# Altium schematic sheet MCU.SchDoc(MCU)
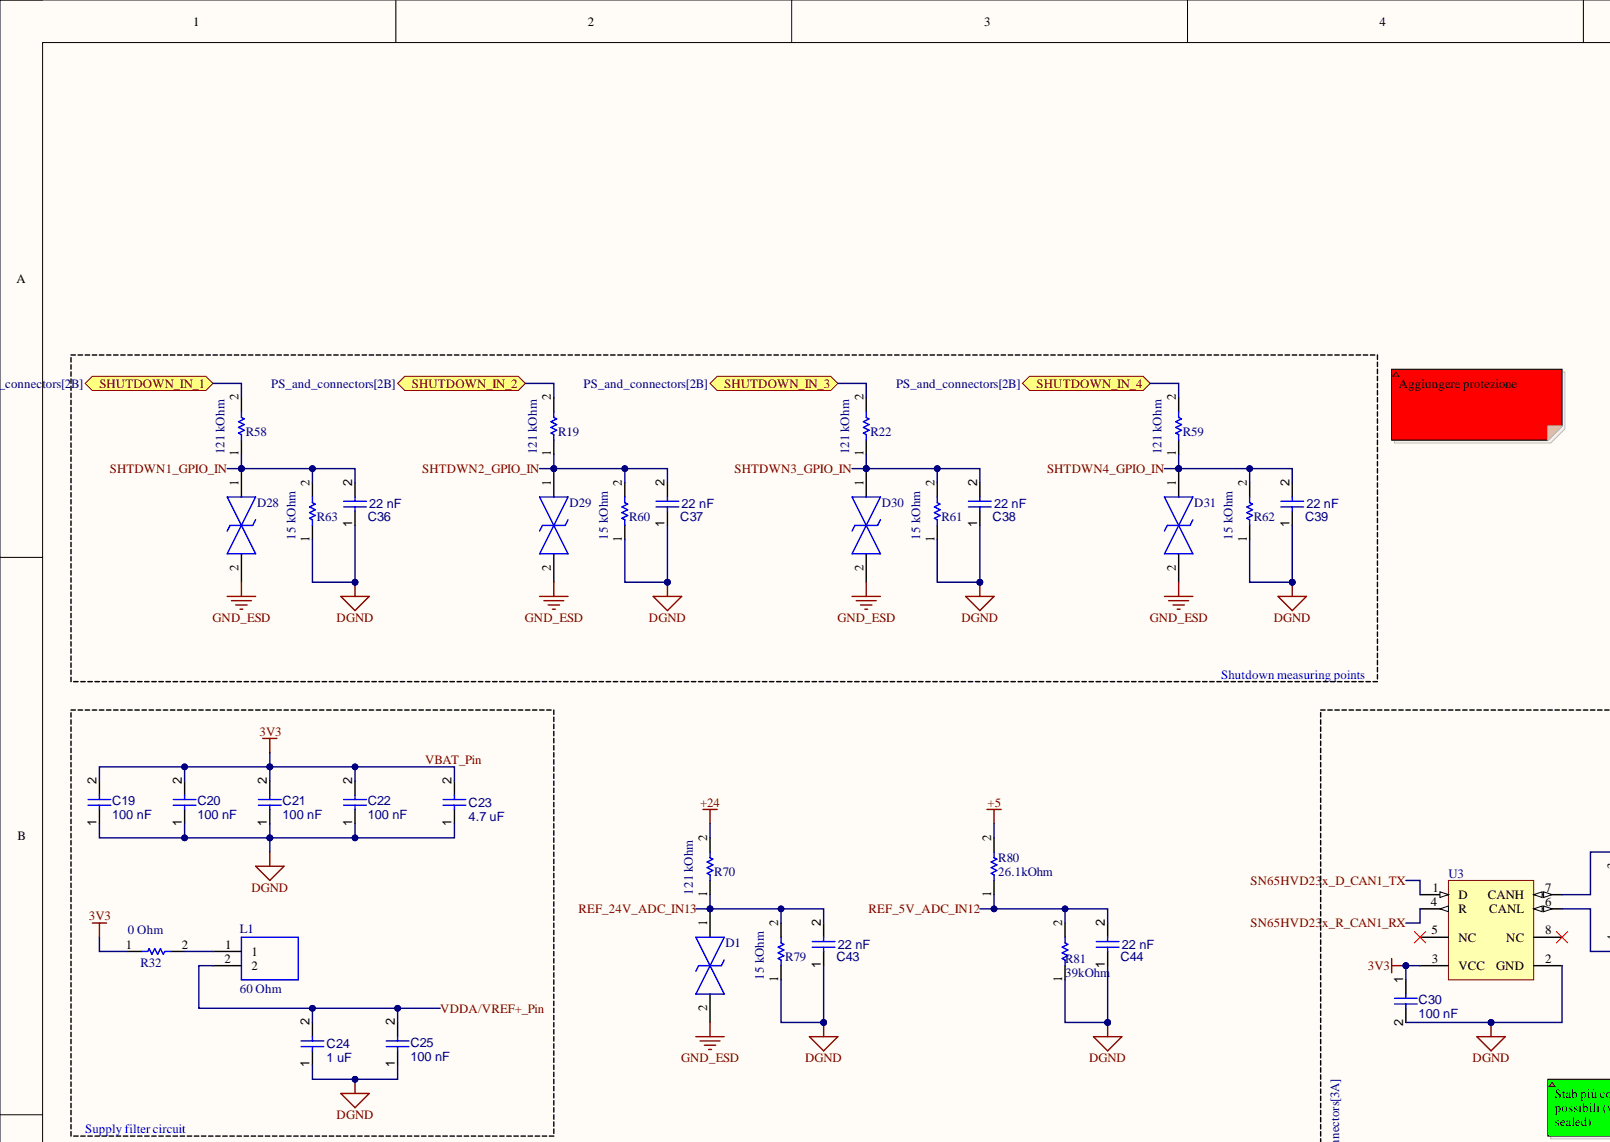
[diagram p0: MCU.SchDoc, top left]
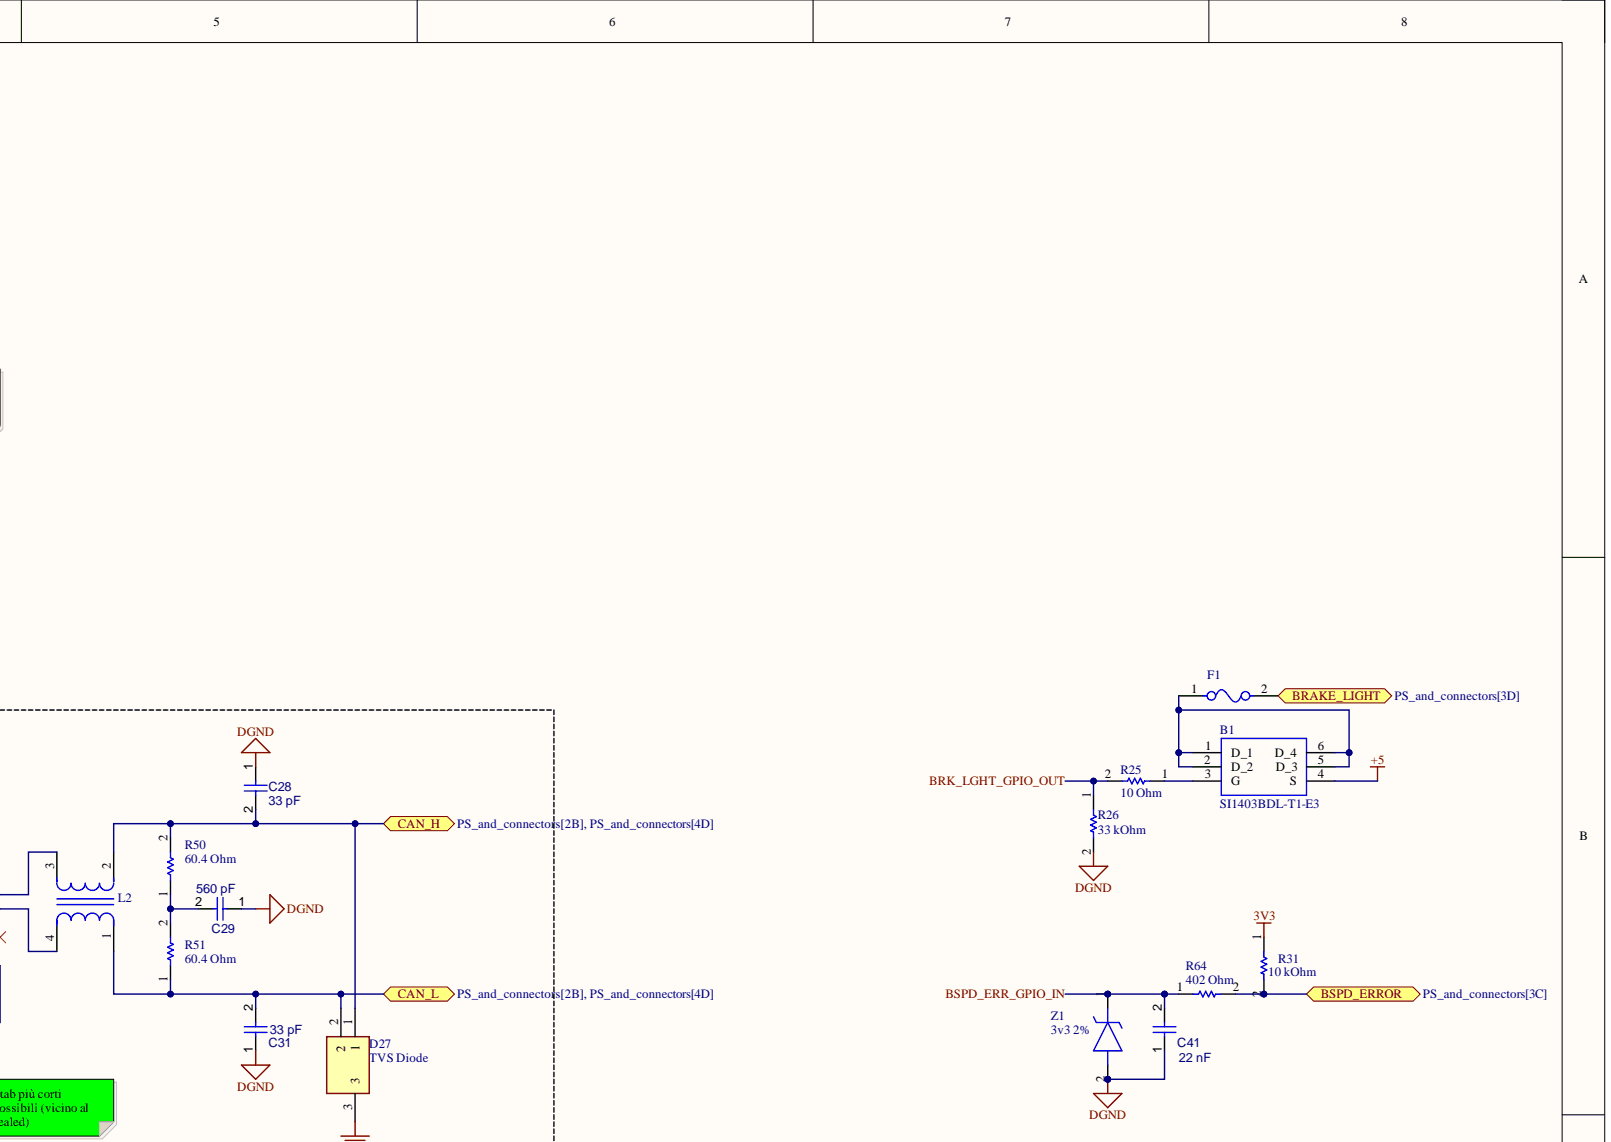
[diagram p1: MCU.SchDoc, top right]
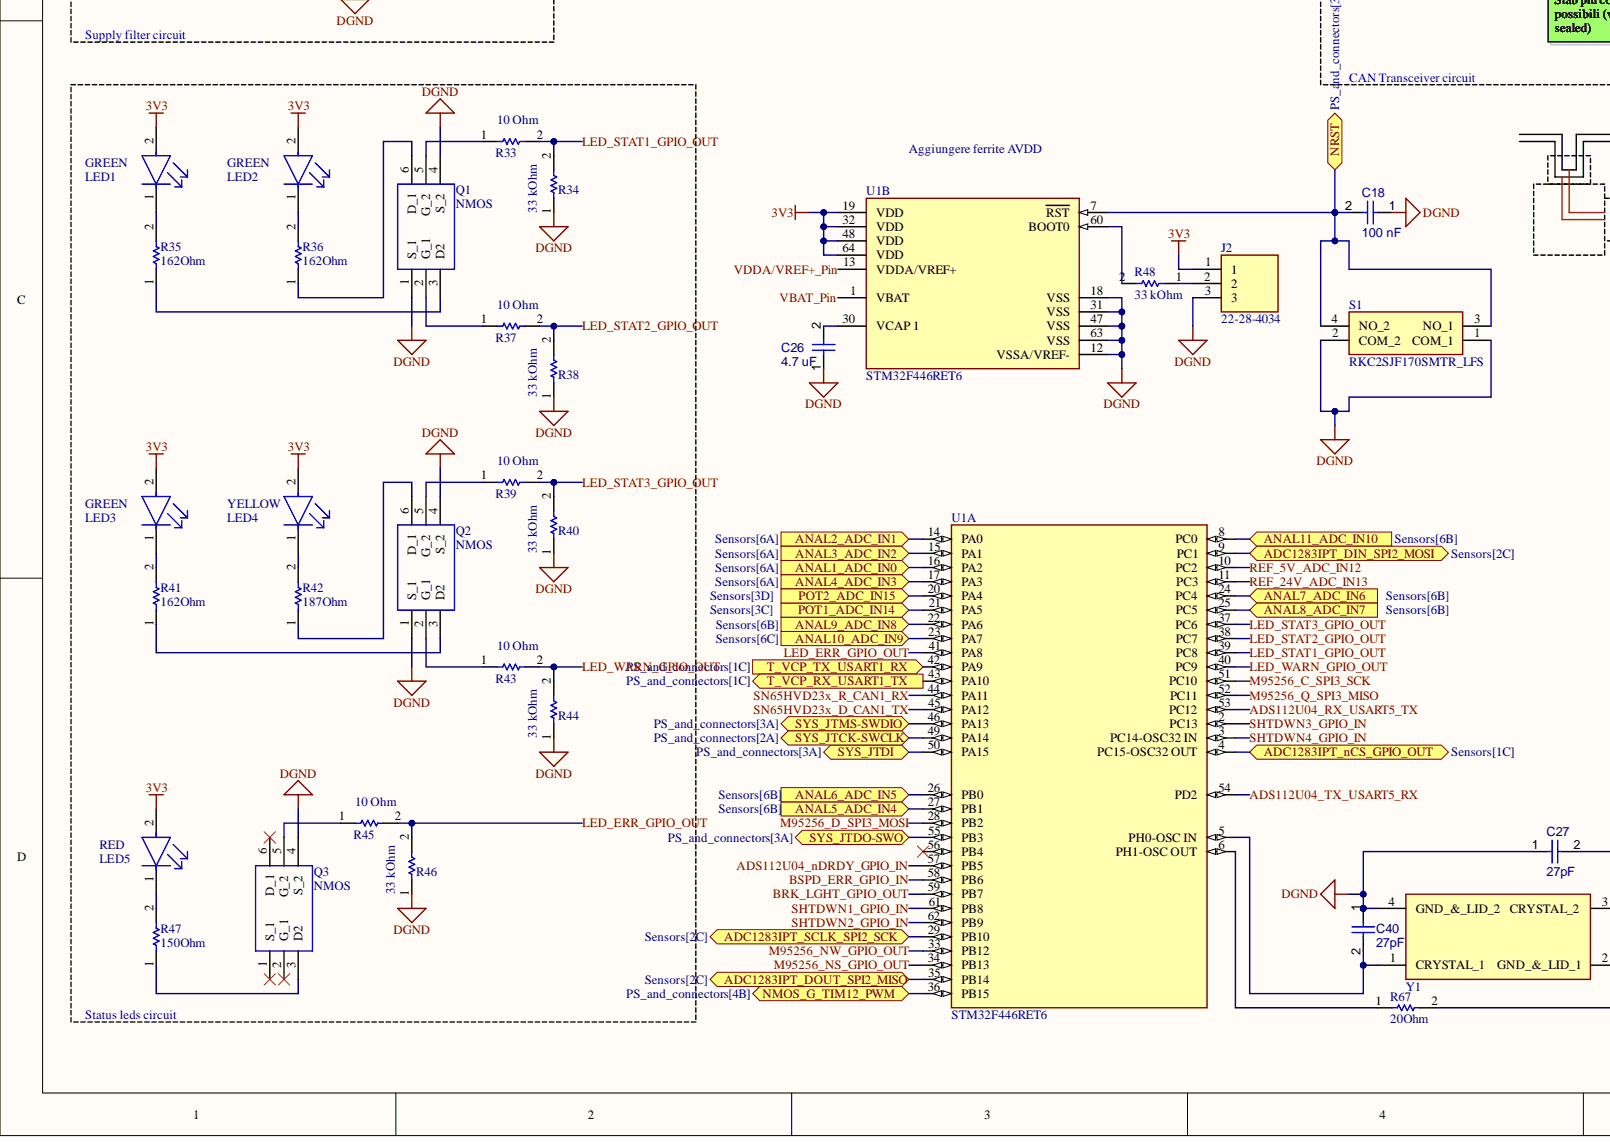
[diagram p2: MCU.SchDoc, bottom left]
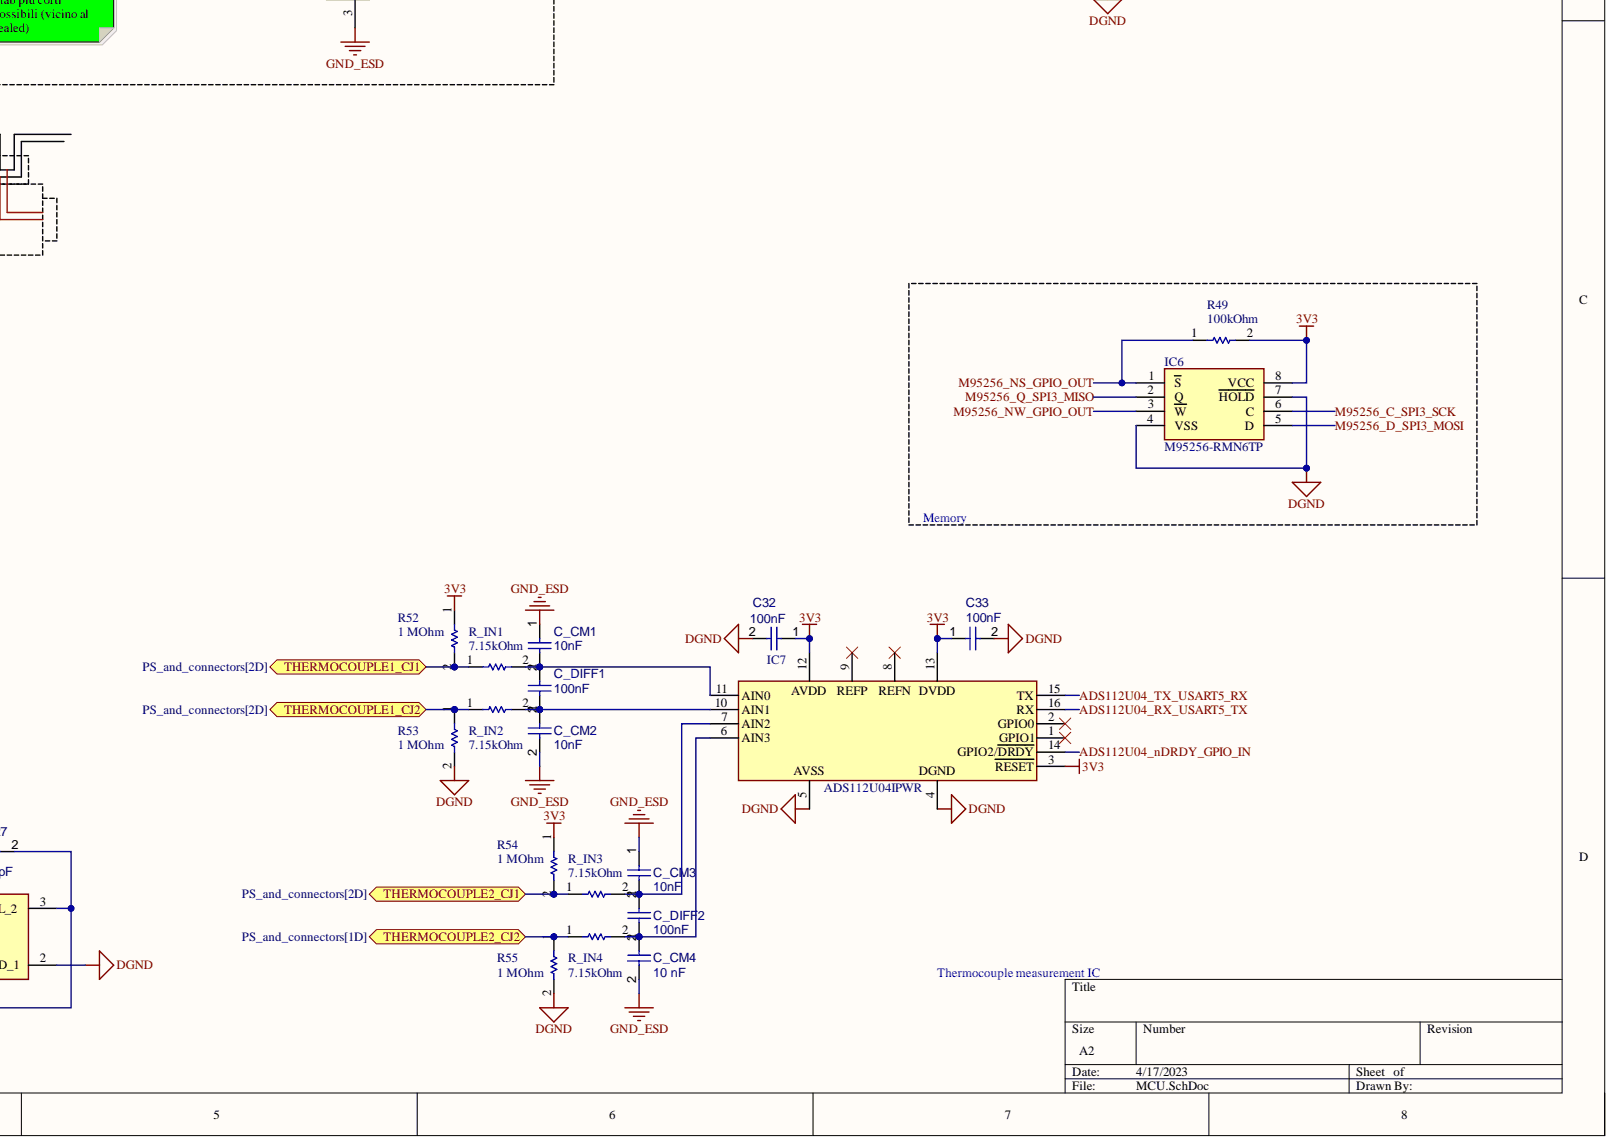
[diagram p3: MCU.SchDoc, bottom right]

## Parts
B1  SI1403BDL-T1-E3
C18  100 nF
C19  100 nF
C20  100 nF
C21  100 nF
C22  100 nF
C23  4.7 uF
C24  1 uF
C25  100 nF
C26  4.7 uF
C27  27pF
C28  33 pF
C29  560 pF
C30  100 nF
C31  33 pF
C32  100nF
C33  100nF
C36  22 nF
C37  22 nF
C38  22 nF
C39  22 nF
C40  27pF
C41  22 nF
C43  22 nF
C44  22 nF
C_CM1  10nF
C_CM2  10nF
C_CM3  10nF
C_CM4  10 nF
C_DIFF1  100nF
C_DIFF2  100nF
D1  SMAJ30CA-TR  (value not drawn)
D27  TVS Diode
D28  SMAJ30CA-TR  (value not drawn)
D29  SMAJ30CA-TR  (value not drawn)
D30  SMAJ30CA-TR  (value not drawn)
D31  SMAJ30CA-TR  (value not drawn)
F1  0466001.NR  (value not drawn)
IC6  M95256-RMN6TP
IC7  ADS112U04IPWR
J2  22-28-4034
L1  60 Ohm
L2  ACT45B-101-2P-TL003  (value not drawn)
LED1  GREEN
LED2  GREEN
LED3  GREEN
LED4  YELLOW
LED5  RED
Q1  NMOS
Q2  NMOS
Q3  NMOS
R19  121 kOhm
R22  121 kOhm
R25  10 Ohm
R26  33 kOhm
R31  10 kOhm
R32  0 Ohm
R33  10 Ohm
R34  33 kOhm
R35  162Ohm
R36  162Ohm
R37  10 Ohm
R38  33 kOhm
R39  10 Ohm
R40  33 kOhm
R41  162Ohm
R42  187Ohm
R43  10 Ohm
R44  33 kOhm
R45  10 Ohm
R46  33 kOhm
R47  150Ohm
R48  33 kOhm
R49  100kOhm
R50  60.4 Ohm
R51  60.4 Ohm
R52  1 MOhm
R53  1 MOhm
R54  1 MOhm
R55  1 MOhm
R58  121 kOhm
R59  121 kOhm
R60  15 kOhm
R61  15 kOhm
R62  15 kOhm
R63  15 kOhm
R64  402 Ohm
R67  20Ohm
R70  121 kOhm
R79  15 kOhm
R80  26.1kOhm
R81  39kOhm
R_IN1  7.15kOhm
R_IN2  7.15kOhm
R_IN3  7.15kOhm
R_IN4  7.15kOhm
S1  RKC2SJF170SMTR_LFS
U1  STM32F446RET6  (parts A,B)
U3  SN65HVD232DRG4  [SN65HVD232]  (value not drawn)
Y1  830055663  (value not drawn)
Z1  3v3 2%

## Ports
ADC1283IPT_DIN_SPI2_MOSI, ADC1283IPT_DOUT_SPI2_MISO, ADC1283IPT_nCS_GPIO_OUT, ADC1283IPT_SCLK_SPI2_SCK, ANAL1_ADC_IN0, ANAL2_ADC_IN1, ANAL3_ADC_IN2, ANAL4_ADC_IN3, ANAL5_ADC_IN4, ANAL6_ADC_IN5, ANAL7_ADC_IN6, ANAL8_ADC_IN7, ANAL9_ADC_IN8, ANAL10_ADC_IN9, ANAL11_ADC_IN10, BRAKE_LIGHT, BSPD_ERROR, CAN_H, CAN_L, NMOS_G_TIM12_PWM, NRST, POT1_ADC_IN14, POT2_ADC_IN15, SHUTDOWN_IN_1, SHUTDOWN_IN_2, SHUTDOWN_IN_3, SHUTDOWN_IN_4, SYS_JTCK-SWCLK, SYS_JTDI, SYS_JTDO-SWO, SYS_JTMS-SWDIO, T_VCP_RX_USART1_TX, T_VCP_TX_USART1_RX, THERMOCOUPLE1_CJ1, THERMOCOUPLE1_CJ2, THERMOCOUPLE2_CJ1, THERMOCOUPLE2_CJ2

## Nets
+5: B1.4(S) R80.2
+24: R70.2
DGND: C18.1 C19.1 C20.1 C21.1 C22.1 C23.1 C24.1 C25.1 C26.1 C27.1 C28.1 C29.1 C30.2 C31.1 C32.2 C33.2 C36.1 C37.1 C38.1 C39.1 C40.1 C41.1 C43.1 C44.1 IC6.4(VSS) IC6.7(HOLD) IC7.4 IC7.5 J2.3 Q1.1(S_1) Q1.4(S_2) Q2.1(S_1) Q2.4(S_2) Q3.4(S_2) R26.2 R34.1 R38.1 R40.1 R44.1 R46.1 R53.2 R55.2 R60.1 R61.1 R62.1 R63.1 R79.1 R81.1 S1.1(COM_1) S1.2(COM_2) U1.12(VSSA/VREF-) U1.18(VSS) U1.31(VSS) U1.47(VSS) U1.63(VSS) U3.2(GND) Y1.2(GND_&_LID_1) Y1.4(GND_&_LID_2) Z1.2
GND_ESD: C_CM1.1 C_CM2.2 C_CM3.1 C_CM4.2 D1.2 D27.3 D28.2 D29.2 D30.2 D31.2
ADC1283IPT_DIN_SPI2_MOSI: U1.9(PC1) ; port ADC1283IPT_DIN_SPI2_MOSI
ADC1283IPT_DOUT_SPI2_MISO: U1.35(PB14) ; port ADC1283IPT_DOUT_SPI2_MISO
ADC1283IPT_nCS_GPIO_OUT: U1.4(PC15-OSC32_OUT) ; port ADC1283IPT_nCS_GPIO_OUT
ADC1283IPT_SCLK_SPI2_SCK: U1.29(PB10) ; port ADC1283IPT_SCLK_SPI2_SCK
ADS112U04_nDRDY_GPIO_IN: IC7.14(GPIO2/DRDY) U1.57(PB5)
ADS112U04_RX_USART5_TX: IC7.16(RX) U1.53(PC12)
ADS112U04_TX_USART5_RX: IC7.15(TX) U1.54(PD2)
ANAL1_ADC_IN0: U1.16(PA2) ; port ANAL1_ADC_IN0
ANAL2_ADC_IN1: U1.14(PA0) ; port ANAL2_ADC_IN1
ANAL3_ADC_IN2: U1.15(PA1) ; port ANAL3_ADC_IN2
ANAL4_ADC_IN3: U1.17(PA3) ; port ANAL4_ADC_IN3
ANAL5_ADC_IN4: U1.27(PB1) ; port ANAL5_ADC_IN4
ANAL6_ADC_IN5: U1.26(PB0) ; port ANAL6_ADC_IN5
ANAL7_ADC_IN6: U1.24(PC4) ; port ANAL7_ADC_IN6
ANAL8_ADC_IN7: U1.25(PC5) ; port ANAL8_ADC_IN7
ANAL9_ADC_IN8: U1.22(PA6) ; port ANAL9_ADC_IN8
ANAL10_ADC_IN9: U1.23(PA7) ; port ANAL10_ADC_IN9
ANAL11_ADC_IN10: U1.8(PC0) ; port ANAL11_ADC_IN10
BRAKE_LIGHT: F1.2 ; port BRAKE_LIGHT
BRK_LGHT_GPIO_OUT: R25.2 R26.1 U1.59(PB7)
BSPD_ERR_GPIO_IN: C41.2 R64.1 U1.58(PB6) Z1.1
BSPD_ERROR: R31.2 R64.2 ; port BSPD_ERROR
CAN_H: C28.2 D27.1 L2.2 R50.2 ; port CAN_H
CAN_L: C31.2 D27.2 L2.1 R51.1 ; port CAN_L
LED_ERR_GPIO_OUT: R45.2 R46.2 U1.41(PA8)
LED_STAT1_GPIO_OUT: R33.2 R34.2 U1.39(PC8)
LED_STAT2_GPIO_OUT: R37.2 R38.2 U1.38(PC7)
LED_STAT3_GPIO_OUT: R39.2 R40.2 U1.37(PC6)
LED_WARN_GPIO_OUT: R43.2 R44.2 U1.40(PC9)
M95256_C_SPI3_SCK: IC6.6(C) U1.51(PC10)
M95256_D_SPI3_MOSI: IC6.5(D) U1.28(PB2)
M95256_NS_GPIO_OUT: IC6.1(S) R49.1 U1.34(PB13)
M95256_NW_GPIO_OUT: IC6.3(W) U1.33(PB12)
M95256_Q_SPI3_MISO: IC6.2(Q) U1.52(PC11)
NMOS_G_TIM12_PWM: U1.36(PB15) ; port NMOS_G_TIM12_PWM
NRST: C18.2 S1.3(NO_1) S1.4(NO_2) U1.7(RST) ; port NRST
POT1_ADC_IN14: U1.21(PA5) ; port POT1_ADC_IN14
POT2_ADC_IN15: U1.20(PA4) ; port POT2_ADC_IN15
REF_5V_ADC_IN12: C44.2 R80.1 R81.2 U1.10(PC2)
REF_24V_ADC_IN13: C43.2 D1.1 R70.1 R79.2 U1.11(PC3)
SHTDWN1_GPIO_IN: C36.2 D28.1 R58.1 R63.2 U1.61(PB8)
SHTDWN2_GPIO_IN: C37.2 D29.1 R19.1 R60.2 U1.62(PB9)
SHTDWN3_GPIO_IN: C38.2 D30.1 R22.1 R61.2 U1.2(PC13)
SHTDWN4_GPIO_IN: C39.2 D31.1 R59.1 R62.2 U1.3(PC14-OSC32_IN)
SHUTDOWN_IN_1: R58.2 ; port SHUTDOWN_IN_1
SHUTDOWN_IN_2: R19.2 ; port SHUTDOWN_IN_2
SHUTDOWN_IN_3: R22.2 ; port SHUTDOWN_IN_3
SHUTDOWN_IN_4: R59.2 ; port SHUTDOWN_IN_4
SN65HVD23x_D_CAN1_TX: U1.45(PA12) U3.1(D)
SN65HVD23x_R_CAN1_RX: U1.44(PA11) U3.4(R)
SYS_JTCK-SWCLK: U1.49(PA14) ; port SYS_JTCK-SWCLK
SYS_JTDI: U1.50(PA15) ; port SYS_JTDI
SYS_JTDO-SWO: U1.55(PB3) ; port SYS_JTDO-SWO
SYS_JTMS-SWDIO: U1.46(PA13) ; port SYS_JTMS-SWDIO
T_VCP_RX_USART1_TX: U1.43(PA10) ; port T_VCP_RX_USART1_TX
T_VCP_TX_USART1_RX: U1.42(PA9) ; port T_VCP_TX_USART1_RX
THERMOCOUPLE1_CJ1: R52.2 R_IN1.1 ; port THERMOCOUPLE1_CJ1
THERMOCOUPLE1_CJ2: R53.1 R_IN2.1 ; port THERMOCOUPLE1_CJ2
THERMOCOUPLE2_CJ1: R54.2 R_IN3.1 ; port THERMOCOUPLE2_CJ1
THERMOCOUPLE2_CJ2: R55.1 R_IN4.1 ; port THERMOCOUPLE2_CJ2
VBAT_Pin: C19.2 C20.2 C21.2 C22.2 C23.2 C30.1 C32.1 C33.1 IC6.8(VCC) IC7.3(RESET) IC7.12 IC7.13 J2.1 LED1.2 LED2.2 LED3.2 LED4.2 LED5.2 R31.1 R32.1 R49.2 R52.1 R54.1 U1.1(VBAT) U1.19(VDD) U1.32(VDD) U1.48(VDD) U1.64(VDD) U3.3(VCC)
VDDA/VREF+_Pin: C24.2 C25.2 L1.2 U1.13(VDDA/VREF+)
NetB1_1: B1.1(D_1) B1.2(D_2) B1.5(D_3) B1.6(D_4) F1.1
NetB1_3: B1.3(G) R25.1
NetC26_2: C26.2 U1.30(VCAP_1)
NetC27_2: C27.2 R67.2 Y1.3(CRYSTAL_2)
NetC29_2: C29.2 R50.1 R51.2
NetC40_2: C40.2 U1.5(PH0-OSC_IN) Y1.1(CRYSTAL_1)
NetC_CM1_2: C_CM1.2 C_DIFF1.1 IC7.11(AIN0) R_IN1.2
NetC_CM2_1: C_CM2.1 C_DIFF1.2 IC7.10(AIN1) R_IN2.2
NetC_CM3_2: C_CM3.2 C_DIFF2.1 IC7.7(AIN2) R_IN3.2
NetC_CM4_1: C_CM4.1 C_DIFF2.2 IC7.6(AIN3) R_IN4.2
NetJ2_2: J2.2 R48.1
NetL1_1: L1.1 R32.2
NetL2_3: L2.3 U3.7(CANH)
NetL2_4: L2.4 U3.6(CANL)
NetLED1_1: LED1.1 R35.2
NetLED2_1: LED2.1 R36.2
NetLED3_1: LED3.1 R41.2
NetLED4_1: LED4.1 R42.2
NetLED5_1: LED5.1 R47.2
NetQ1_2: Q1.2(G_1) R37.1
NetQ1_3: Q1.3(D2) R35.1
NetQ1_5: Q1.5(G_2) R33.1
NetQ1_6: Q1.6(D_1) R36.1
NetQ2_2: Q2.2(G_1) R43.1
NetQ2_3: Q2.3(D2) R41.1
NetQ2_5: Q2.5(G_2) R39.1
NetQ2_6: Q2.6(D_1) R42.1
NetQ3_3: Q3.3(D2) R47.1
NetQ3_5: Q3.5(G_2) R45.1
NetR48_2: R48.2 U1.60(BOOT0)
NetR67_1: R67.1 U1.6(PH1-OSC_OUT)

Not connected: IC7 1(GPIO1) 2(GPIO0) 8 9; Q3 1(S_1) 2(G_1) 6(D_1); U1 56(PB4); U3 5(NC) 8(NC)

## Library data of the parts
B1 = CMP-002-000009-1: SI1403BDL-T1-E3, P-channel MOSFET Transistor 1.4 A 20 V, 6-Pin SOT-363; Manufacturer_Name: Vishay; Geometry.Height: 1.1mm; Component Kind: Standard; Datasheet Link: https://datasheet.datasheetarchive.com/originals/distributors/Datasheets-DGA23/1587687.pdf; Design Item ID: SI1403BDL-T1-E3; Manufacturer_Part_Number: SI1403BDL-T1-E3; Mouser Part Number: 781-SI1403BDL-T1-E3; Mouser Price/Stock: https://www.mouser.co.uk/ProductDetail/Vishay-Semiconductors/SI1403BDL-T1-E3?qs=BuP3DjGpy25sS7bu2zwY4A%3D%3D; Source: SI1403BDL-T1-E3.SchLib; Library Name: EMEA- Squadra Corse PoliTO-  Politecnico di Torino
C18-C22 C25 C30 C32 C33 C_DIFF1 C_DIFF2 = CMP-001-00085-2: VJ0805Y104MXATW1BC; Tech: SMD; Component Kind: Standard; ComponentLink1Description: Website; Package: 0805; Library Name: EMEA- Squadra Corse PoliTO-  Politecnico di Torino
  C18-C22 C25 C30: ComponentLink1URL: https://www.mouser.it/ProductDetail/Vishay-Vitramon/VJ0805Y104KBABE31?qs=TLaLXeh6c70yB%252BsdRZoYCQ%3D%3D
C23 C26 = CMP-001-00085-2: GRM21BR71C475KE51K; Tech: SMD; Component Kind: Standard; ComponentLink1Description: Website; ComponentLink1URL: https://www.mouser.it/ProductDetail/Vishay-Vitramon/VJ0805G475MXXTW1BC?qs=PqoDHHvF6488%252BUk4EHTgKw%3D%3D; Package: 0805; Library Name: EMEA- Squadra Corse PoliTO-  Politecnico di Torino
C24 = CMP-001-00085-2: VJ0805Y105MXQTW1BC; Tech: SMD; Component Kind: Standard; ComponentLink1Description: Website; ComponentLink1URL: https://www.mouser.it/ProductDetail/Vishay-Vitramon/VJ0805V105MXQPW1BC?qs=wtJBjE46HQsbbuoG%2F6UKXw%3D%3D; Package: 0805; Library Name: EMEA- Squadra Corse PoliTO-  Politecnico di Torino
C27 C40 = CMP-001-00085-2: VJ0805A270FXXPW1BC; Tech: SMD; Component Kind: Standard; ComponentLink1Description: Website; Package: 0805; Library Name: EMEA- Squadra Corse PoliTO-  Politecnico di Torino
C28 C31 = CMP-001-00085-2: VJ0805A330JXACW1BC; Tech: SMD; Component Kind: Standard; ComponentLink1Description: Website; ComponentLink1URL: https://www.mouser.it/ProductDetail/Vishay-Vitramon/VJ0805A330JXACW1BC?qs=5Rws4bj8rAGiHl%252BySR4oOA%3D%3D; Package: 0805; Library Name: EMEA- Squadra Corse PoliTO-  Politecnico di Torino
C29 = CMP-001-00085-2: VJ0805D561JXXQJHT; Tech: SMD; Component Kind: Standard; ComponentLink1Description: Website; ComponentLink1URL: https://www.mouser.it/ProductDetail/Vishay-Vitramon/VJ0805Y561JXXPW1BC?qs=RHlxhb6sQsJIXs1Iyq971g%3D%3D; Package: 0805; Library Name: EMEA- Squadra Corse PoliTO-  Politecnico di Torino
C36-C39 C41 C43 C44 = CMP-001-00085-2: VJ0805Y223JXXCW1BC; Tech: SMD; Component Kind: Standard; ComponentLink1Description: Website; ComponentLink1URL: https://www.mouser.it/ProductDetail/Vishay-Vitramon/VJ0805Y223JXXPW1BC?qs=dYENeDlLSFWEZ4cdRF5phA%3D%3D; Package: 0805; Library Name: EMEA- Squadra Corse PoliTO-  Politecnico di Torino
C_CM1-C_CM4 = CMP-001-00085-2: VJ0805H103KXBBC31; Tech: SMD; Component Kind: Standard; ComponentLink1Description: Website; Package: 0805; Library Name: EMEA- Squadra Corse PoliTO-  Politecnico di Torino
D1 D28-D31 = CMP-004-000005-1: TVS Diode Bi-Directional SMAJ30CA-TR 64.3V; Manufacturer_Name: STMicroelectronics; Arrow Part Number: SMAJ30CA-TR; Arrow Price/Stock: https://www.arrow.com/en/products/smaj30ca-tr/stmicroelectronics?region=nac; Component Kind: Standard; Datasheet Link: https://www.st.com/resource/en/datasheet/smaj10ca.pdf; Design Item ID: SMAJ30CA-TR; Height: 2.65mm; Manufacturer_Part_Number: SMAJ30CA-TR; Mouser Part Number: 511-SMAJ30CA-TR; Mouser Price/Stock: https://www.mouser.co.uk/ProductDetail/STMicroelectronics/SMAJ30CA-TR?qs=0TJjK1waviDY0EkLaKH12g%3D%3D; Source: SamacSys.SchLib; Library Name: EMEA- Squadra Corse PoliTO-  Politecnico di Torino
D27 = CMP-030-000036-3: ESDCAN24-2BLY; Tech: SMD; Component Kind: Standard; Package: SOT-23-3; Library Name: EMEA- Squadra Corse PoliTO-  Politecnico di Torino
F1 = CMP-08605-000058-1: FUSE BOARD MNT 1A 63VAC/VDC 1206; Manufacturer: Littelfuse Inc; Fuse Blow Speed: Fast; Fuse I2T: 0.0423A2Sec; Fuse Interrupt Ac Current: 50A; Fuse Voltage Drop: 79.97mV; Max Fuse Ac Voltage: 63V; Resistance: 0.075Ω; Value: 0.075Ω; Automotive: No; Category: Fuse; Component Kind: Standard; ComponentLink2Description: Datasheet; ComponentLink2URL: https://www.littelfuse.com/~/media/electronics/datasheets/fuses/littelfuse_fuse_466_datasheet.pdf.pdf; Device Class L1: Circuit Protection; Device Class L2: Fuses; Device Class L3: unset; Digikey Description: FUSE BOARD MNT 1A 63VAC/VDC 1206; Fuse Current: 1A; Fuse Interrupt Dc Current: 50A; Height: 0.66mm; Lead Free: Yes; Library Name: Altium Content Vault; Manufacturer Part Number: 0466001.NR; Max Fuse Dc Voltage: 63V; Mouser Description: Surface Mount Fuses 63V FA 1206 1A VFA Slimline; Octopart: https://octopart.com/0466001.nr-littelfuse-566005; Package: 0466; RoHS: TRUE; Temperature: -55°C to +90°C; Temperature Range High: +90°C; Temperature Range Low: -55°C; Voltage Rating: 63V
IC6 = CMP-007-000006-1: 256-Kbit serial SPI bus EEPROM with high-speed clock; Manufacturer_Name: STMicroelectronics; Arrow Part Number: M95256-RMN6TP; Arrow Price/Stock: https://www.arrow.com/en/products/m95256-rmn6tp/stmicroelectronics; Component Kind: Standard; Datasheet Link: https://datasheet.lcsc.com/szlcsc/1812011607_STMicroelectronics-M95256-RMN6TP_C140883.pdf; Design Item ID: M95256-RMN6TP; Height: 1.75mm; Manufacturer_Part_Number: M95256-RMN6TP; Mouser Part Number: 511-M95256-RMN6TP; Mouser Price/Stock: https://www.mouser.co.uk/ProductDetail/STMicroelectronics/M95256-RMN6TP?qs=6hSH1YZbOIgt2lfjA1S%252B0g%3D%3D; Source: SamacSys.SchLib; Library Name: EMEA- Squadra Corse PoliTO-  Politecnico di Torino
IC7 = CMP-007-000008-2: 16-Bit; Manufacturer_Name: Texas Instruments; Arrow Part Number: ADS112U04IPWR; Arrow Price/Stock: https://www.arrow.com/en/products/ads112u04ipwr/texas-instruments?region=nac; Component Kind: Standard; Datasheet Link: http://www.ti.com/lit/gpn/ads112u04; Design Item ID: ADS112U04IPWR; Height: 1.2mm; Manufacturer_Part_Number: ADS112U04IPWR; Mouser Part Number: 595-ADS112U04IPWR; Mouser Price/Stock: https://www.mouser.co.uk/ProductDetail/Texas-Instruments/ADS112U04IPWR?qs=rrS6PyfT74fPyJ78HLCbmA%3D%3D; Source: SamacSys.SchLib; Library Name: EMEA- Squadra Corse PoliTO-  Politecnico di Torino
J2 = CMP-003-000007-1: Headers & Wire Housings 3P HEADER 15u Au; Manufacturer_Name: Molex; Component Kind: Standard; Datasheet Link: http://www.farnell.com/datasheets/2695379.pdf; Design Item ID: 22-28-4034; Height: 10.42mm; Manufacturer_Part_Number: 22-28-4034; Mouser Part Number: 538-22-28-4034; Mouser Price/Stock: https://www.mouser.co.uk/ProductDetail/Molex/22-28-4034?qs=d9fIA1aNikSe4sPe0kcxOw%3D%3D; Source: SamacSys.SchLib; Library Name: EMEA- Squadra Corse PoliTO-  Politecnico di Torino
L1 = CMP-056-000000-1: ILHB0805ER600V; Tech: SMD; Component Kind: Standard; Package: 0805; Library Name: EMEA- Squadra Corse PoliTO-  Politecnico di Torino
L2 = CMP-08256-000007-1: CMC 100UH 150MA 2LN SMD AEC-Q200; Manufacturer: TDK; Automotive Grade: Grade 0; Impedance At Frequency: 5800Ω @ 10MHz; Rms Current: 0.15A; Series Resistance: 2Ω; Test Frequency: 100kHz; Tolerance: +50/-30%; Automotive: Yes; Category: Ind; Component Kind: Standard; ComponentLink2Description: Datasheet; ComponentLink2URL: https://product.tdk.com/info/en/catalog/datasheets/cmf_automotive_signal_act45b_en.pdf; Composition: Wirewound; Device Class L1: Passive Components; Device Class L2: EMI / RFI Components; Device Class L3: Common Mode Chokes; Digikey Description: CMC 100UH 150MA 2LN SMD AEC-Q200; Height: 3mm; Inductance: 100uH; Lead Free: Yes; Library Name: Altium Content Vault; Manufacturer Part Number: ACT45B-101-2P-TL003; Mouser Description: Common Mode Chokes / Filters 5000ohms 100uH 150mA CAN-BUS AEC-Q200; Octopart: https://octopart.com/act45b-101-2p-tl003-tdk-11353565; Package: ACT45B; RoHS: TRUE; Temperature: -40°C to +150°C; Temperature Range High: +150°C; Temperature Range Low: -40°C; Value: 100uH
LED1-LED3 = CMP-007-00036-1: VLMTG1401-GS08; Tech: SMD; Component Kind: Standard; ComponentLink1Description: Website; ComponentLink1URL: https://www.mouser.it/ProductDetail/Vishay-Semiconductors/VLMO1300-GS08?qs=uoVnq4yU%252B9LGm9D7t9Wc3Q%3D%3D; Package: 0603; Library Name: EMEA- Squadra Corse PoliTO-  Politecnico di Torino
LED4 = CMP-007-00036-1: VLMG1300-GS08; Tech: SMD; Component Kind: Standard; ComponentLink1Description: Website; ComponentLink1URL: https://www.mouser.it/ProductDetail/Vishay-Semiconductors/VLMO1300-GS08?qs=uoVnq4yU%252B9LGm9D7t9Wc3Q%3D%3D; Package: 0603; Library Name: EMEA- Squadra Corse PoliTO-  Politecnico di Torino
LED5 = CMP-007-00036-1: VLMS1300-GS08; Tech: SMD; Component Kind: Standard; ComponentLink1Description: Website; ComponentLink1URL: https://www.mouser.it/ProductDetail/Vishay-Semiconductors/VLMO1300-GS08?qs=uoVnq4yU%252B9LGm9D7t9Wc3Q%3D%3D; Package: 0603; Library Name: EMEA- Squadra Corse PoliTO-  Politecnico di Torino
Q1-Q3 = CMP-069-000000-2: SI1926DL-T1-GE3; Tech: SMD; Component Kind: Standard; ComponentLink1Description: Website; ComponentLink1URL: https://www.mouser.it/ProductDetail/Vishay-Semiconductors/SI1926DL-T1-GE3?qs=FfLXECYddQSjYFoPhKd4yw%3D%3D; Package: SOT-363-6; Library Name: EMEA- Squadra Corse PoliTO-  Politecnico di Torino
R19 R22 R58 R59 R70 = CMP-009-00179-4: CRCW0603121KFKEB; Tech: SMD; Component Kind: Standard; ComponentLink1Description: Website; ComponentLink1URL: https://www.mouser.it/ProductDetail/Vishay-Dale/CRCW0603121KFKEA?qs=SNwsiWikH8rxaNKbUsRIDA%3D%3D; Package: 0603; Library Name: EMEA- Squadra Corse PoliTO-  Politecnico di Torino
R25 R33 R37 R39 R43 R45 = CMP-009-00179-4: RC0603FR-7W10RL; Tech: SMD; Component Kind: Standard; ComponentLink1Description: Website; ComponentLink1URL: https://www.mouser.it/ProductDetail/Vishay-Dale/CRCW060310R0FKEAHP?qs=k2%2FDWSARqgHKJ7AdmQKyZw%3D%3D; Package: 0603; Library Name: EMEA- Squadra Corse PoliTO-  Politecnico di Torino
R26 R34 R38 R40 R44 R46 R48 = CMP-009-00179-4: RCS060333K0FKEA; Tech: SMD; Component Kind: Standard; ComponentLink1Description: Website; ComponentLink1URL: https://www.mouser.it/ProductDetail/Vishay-Dale/RCS060333K0FKEA?qs=yDQnu9hAfI9uIG1%252BkBaBdg%3D%3D; Package: 0603; Library Name: EMEA- Squadra Corse PoliTO-  Politecnico di Torino
R31 = CMP-009-00179-4: RC1206FR-0710KL; Tech: SMD; Component Kind: Standard; ComponentLink1Description: Website; Package: 0603; Library Name: EMEA- Squadra Corse PoliTO-  Politecnico di Torino
R32 = CMP-009-00181-1: CRCW12060000Z0EC; Tech: SMD; Component Kind: Standard; ComponentLink1Description: Website; ComponentLink1URL: https://www.mouser.it/ProductDetail/Vishay-Dale/CRCW12060000Z0EC?qs=y5m63dMNjqsQ4AM4mRfukg%3D%3D; Package: 1206; Library Name: EMEA- Squadra Corse PoliTO-  Politecnico di Torino
R35 R36 R41 = CMP-009-00179-4: CRCW0603162RFKEA; Tech: SMD; Component Kind: Standard; ComponentLink1Description: Website; ComponentLink1URL: https://www.mouser.it/ProductDetail/Vishay-Dale/CRCW0603866RFKEA?qs=wtJBjE46HQv%2FEQq4OglnCg%3D%3D; Package: 0603; Library Name: EMEA- Squadra Corse PoliTO-  Politecnico di Torino
R42 = CMP-009-00179-4: CRCW0603187RFKEA; Tech: SMD; Component Kind: Standard; ComponentLink1Description: Website; ComponentLink1URL: https://www.mouser.it/ProductDetail/Vishay-Dale/CRCW0603866RFKEA?qs=wtJBjE46HQv%2FEQq4OglnCg%3D%3D; Package: 0603; Library Name: EMEA- Squadra Corse PoliTO-  Politecnico di Torino
R47 = CMP-009-00179-4: CRCW0603150RFKEAHP; Tech: SMD; Component Kind: Standard; ComponentLink1Description: Website; ComponentLink1URL: https://www.mouser.it/ProductDetail/Vishay-Dale/CRCW0603866RFKEA?qs=wtJBjE46HQv%2FEQq4OglnCg%3D%3D; Package: 0603; Library Name: EMEA- Squadra Corse PoliTO-  Politecnico di Torino
R49 = CMP-009-00179-4: RT0603FRE13100KL; Tech: SMD; Component Kind: Standard; ComponentLink1Description: Website; Package: 0603; Library Name: EMEA- Squadra Corse PoliTO-  Politecnico di Torino
R50 R51 = CMP-009-00179-4: CRCW060360R4FKEA; Tech: SMD; Component Kind: Standard; ComponentLink1Description: Website; ComponentLink1URL: https://www.mouser.it/ProductDetail/Vishay-Dale/CRCW060360R4FKEA?qs=6fyMJ9%2FiJ9KMVX8MBWVfDg%3D%3D; Package: 0603; Library Name: EMEA- Squadra Corse PoliTO-  Politecnico di Torino
R52-R55 = CMP-009-00179-4: AC0603FR-071ML; Tech: SMD; Component Kind: Standard; ComponentLink1Description: Website; Package: 0603; Library Name: EMEA- Squadra Corse PoliTO-  Politecnico di Torino
R60-R63 R79 = CMP-009-00179-4: CRCW060315K0FKEA; Tech: SMD; Component Kind: Standard; ComponentLink1Description: Website; ComponentLink1URL: https://www.mouser.it/ProductDetail/Vishay-Dale/CRCW060318K7FKEA?qs=9qnzKDpA3VA15sIAY%252B8syw%3D%3D; Package: 0603; Library Name: EMEA- Squadra Corse PoliTO-  Politecnico di Torino
R64 = CMP-009-00179-4: CRCW0603402RFKEA; Tech: SMD; Component Kind: Standard; ComponentLink1Description: Website; Package: 0603; Library Name: EMEA- Squadra Corse PoliTO-  Politecnico di Torino
R67 = CMP-009-00179-4: CRCW060320R0FKEAC; Tech: SMD; Component Kind: Standard; ComponentLink1Description: Website; Package: 0603; Library Name: EMEA- Squadra Corse PoliTO-  Politecnico di Torino
R80 = CMP-009-00179-4: RT0603BRD0726K1L; Tech: SMD; Component Kind: Standard; ComponentLink1Description: Website; Package: 0603; Library Name: EMEA- Squadra Corse PoliTO-  Politecnico di Torino
R81 = CMP-009-00179-4: RT0603BRD0739KL; Tech: SMD; Component Kind: Standard; ComponentLink1Description: Website; Package: 0603; Library Name: EMEA- Squadra Corse PoliTO-  Politecnico di Torino
R_IN1-R_IN4 = CMP-009-00179-4: AC0603FR-077K15L; Tech: SMD; Component Kind: Standard; ComponentLink1Description: Website; Package: 0603; Library Name: EMEA- Squadra Corse PoliTO-  Politecnico di Torino
S1 = CMP-011-000004-3: Tactile Switches; Manufacturer_Name: C & K COMPONENTS; Manufacturer_Part_Number: RKC2SJF170SMTR LFS; Arrow Part Number: RKC2SJF170SMTR LFS; Arrow Price/Stock: https://www.arrow.com/en/products/rkc2sjf170smtr-lfs/ck?region=nac; Component Kind: Standard; Datasheet Link: https://www.ckswitches.com/media/3470/ck_rkc2_datasheet.pdf; Design Item ID: RKC2SJF170SMTR_LFS; Height: 1.9mm; Mouser Part Number: 611-RKC2SJF170SMRLFS; Mouser Price/Stock: https://www.mouser.co.uk/ProductDetail/CK/RKC2SJF170SMTR-LFS?qs=XAiT9M5g4x%252BRRI9derenng%3D%3D; Source: SamacSys.SchLib; Library Name: EMEA- Squadra Corse PoliTO-  Politecnico di Torino
U1 = CMP-12105-000007-1: IC MCU 32BIT 512KB FLASH 64LQFP; Manufacturer: ST; Core Architecture: ARM Cortex-M4; Data Bus Width: 32bits; Frequency: 180MHz; Interface: I2C,SPI,UART,USART,CAN,USB,LIN,IrDA,I2S,Other; Max Junction Temp: +105°C; Max Supply Voltage: 3.6V; Memory Size: 512KBytes; Min Supply Voltage: 1.7V; Number Of A/D Converters: 3; Number Of Adc Channels: 16; Number Of Cores: 1; Number Of I2C Channels: 4; Number Of I/Os: 50; Number Of Pwm Channels: 32; Number Of Timers/Counters: 14; Number Of Uart Channels: 6; Number Of Usb Channels: 2; Ram Size: 128KBytes; Standoff Height: 0.05mm; Ambient Temperature Range High: +85°C; Ambient Temperature Range Low: -40°C; Automotive: No; Category: IC; Component Kind: Standard; ComponentLink2Description: Datasheet; ComponentLink2URL: https://www.st.com/en/microcontrollers-microprocessors/stm32f446re.html; Device Class L1: Integrated Circuits (ICs); Device Class L2: Embedded Processors and Controllers; Device Class L3: Microcontrollers; Digikey Description: IC MCU 32BIT 512KB FLASH 64LQFP; Height: 1.6mm; Ipc Land Pattern Name: QFP50P1200X1200X160-64; Lead Free: Yes; Library Name: Altium Content Vault; Manufacturer Part Number: STM32F446RET6; Memory Type: FLASH; Mouser Description: ARM Microcontrollers - MCU High-performance and DSP with FP, ARM Cortex-M4 MCU with 512 Kbytes Flash, 180 MHz CPU, Art Accelerator, SDRAM; Number Of Spi Channels: 4; Octopart: https://octopart.com/stm32f446ret6-stmicroelectronics-52642920; Package: LQFP64-5W; RoHS: TRUE; Temperature: -40°C to +105°C; Temperature Range High: +105°C; Temperature Range Low: -40°C
U3 = CMP-0315-00080-1: 3.3 V Can Transceiver, 17 mA, -40 to 85 degC, 8-pin SOIC (D), Green (RoHS & no Sb/Br); Manufacturer: Texas Instruments; Drivers Per Package: 1; ICC(Max)(mA): 17; Rating: Catalog; Supply Voltage(s)(V): 3.3; Transient Pulse Protection(V): -25 to 25; Code_JEDEC: MS-012AA; Component Kind: Standard; ComponentLink1Description: Datasheet; ComponentLink1URL: http://www.ti.com/general/docs/lit/getliterature.tsp?genericPartNumber=SN65HVD232&fileType=pdf; DatasheetVersion: SLOS346K; Eco-Plan: Green (RoHS & no Sb/Br); Isolated: No; Library Name: Altium Content Vault; Mounting Technology: Surface Mount; PackageDescription: 8-Pin Plastic Small Outline Integrated Circuit, 4.9 x 3.9 mm, Pitch 1.27 mm Pitch; PackageReference: D8; PackageVersion: revM, Jun-2011; PartNumber: SN65HVD232DRG4; Receivers Per Package: 1; SubFamily: CAN
Y1 = CMP-004-000011-3: Crystals WE-XTAL 8.0MHz 30ppm 5.0 x 3.2mm; Manufacturer_Name: Wurth Elektronik; Component Kind: Standard; Datasheet Link: https://www.we-online.com/catalog/datasheet/830055663.pdf; Design Item ID: 830055663; Height: 1mm; Manufacturer_Part_Number: 830055663; Mouser Part Number: 710-830055663; Mouser Price/Stock: https://www.mouser.co.uk/ProductDetail/Wurth-Elektronik/830055663?qs=2WXlatMagcHXGqDkS%2FTHKQ%3D%3D; Source: SamacSys.SchLib; Library Name: EMEA- Squadra Corse PoliTO-  Politecnico di Torino
Z1 = CMP-011-000001-1: MMSZ5226C-HE3-08; Manufacturer_Name: Vishay; Arrow Part Number: MMSZ5226C-HE3-08; Arrow Price/Stock: https://www.arrow.com/en/products/mmsz5226c-he3-08/vishay?region=nac; Component Kind: Standard; Datasheet Link: https://www.arrow.com/en/products/mmsz5226c-he3-08/vishay; Design Item ID: MMSZ5226C-HE3-08; Height: 1.35mm; Manufacturer_Part_Number: MMSZ5226C-HE3-08; Mouser Part Number: 78-MMSZ5226C-HE3-08; Mouser Price/Stock: https://www.mouser.co.uk/ProductDetail/Vishay-Semiconductors/MMSZ5226C-HE3-08?qs=ESFF34UzhwgdfTXz%2Fhjhlg%3D%3D; Source: SamacSys.SchLib; Library Name: EMEA- Squadra Corse PoliTO-  Politecnico di Torino
